# Altium schematic sheet HALL_SENSOR.SchDoc(HALL_SENSOR)
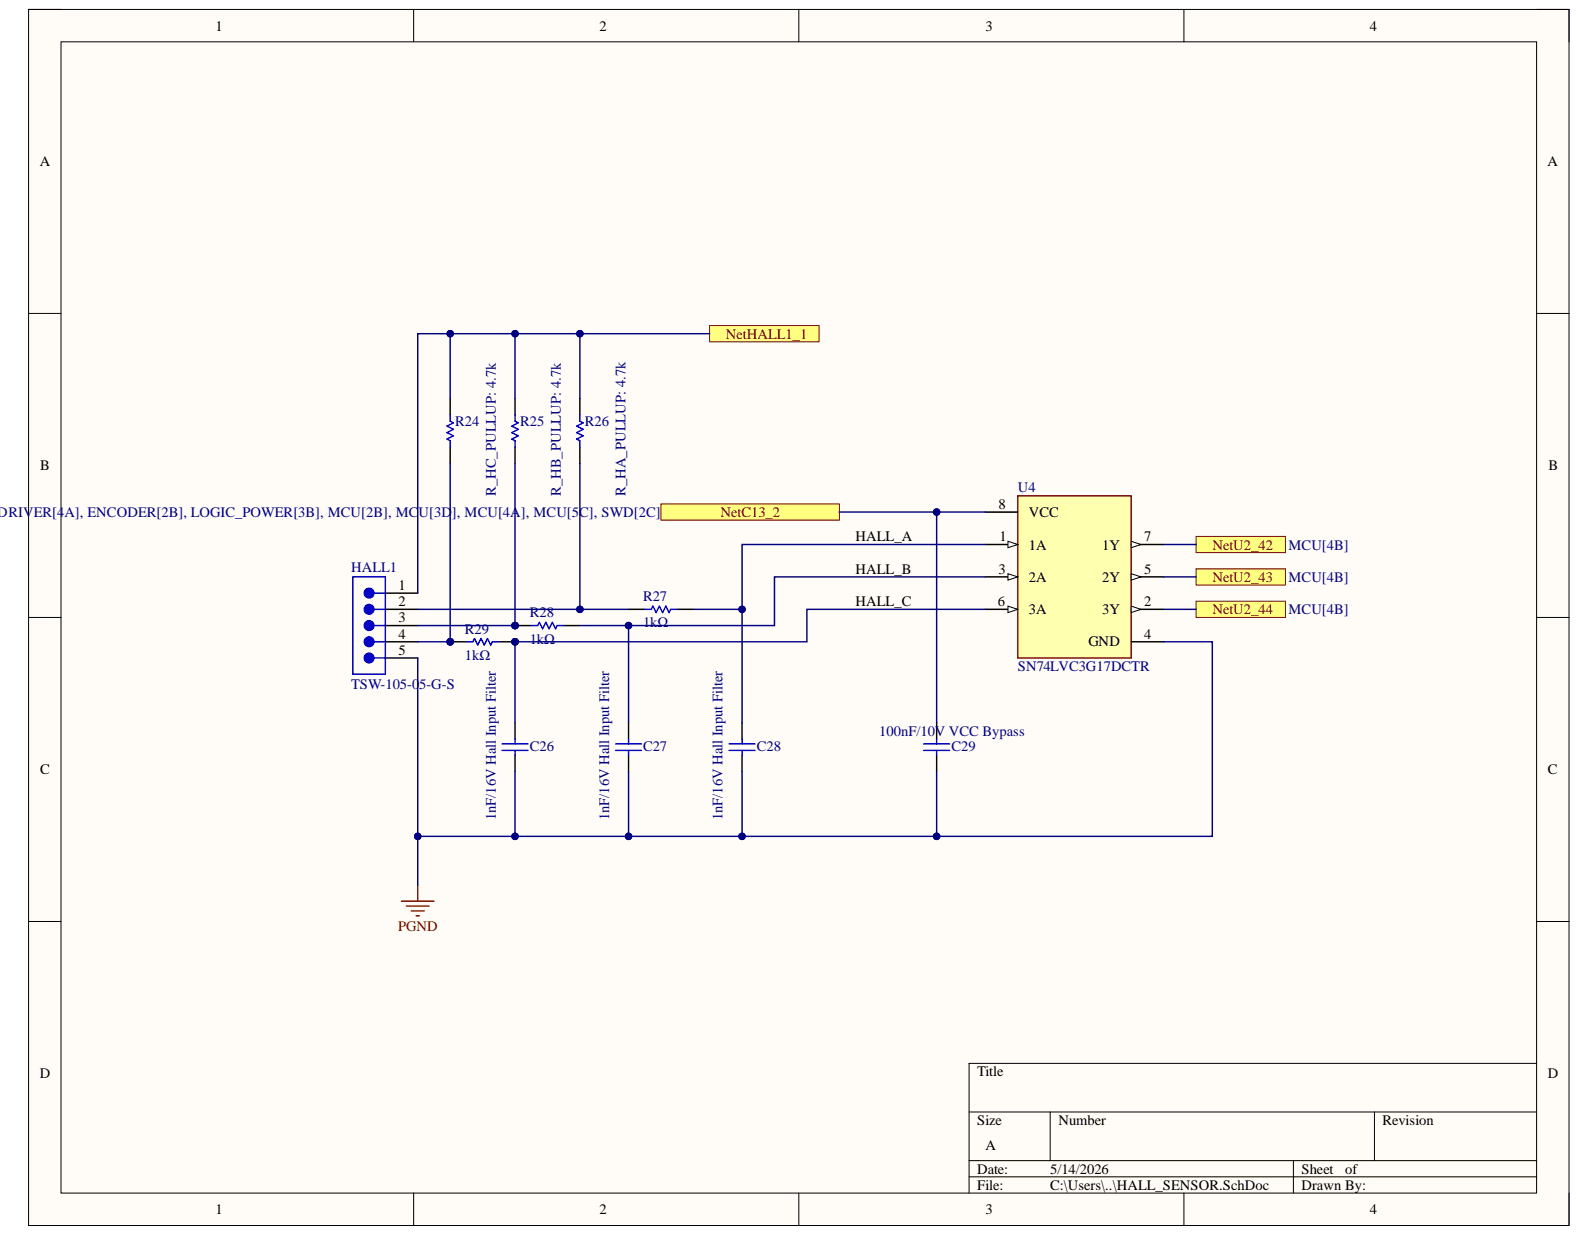
[diagram p0: HALL_SENSOR.SchDoc]

## Parts
C26  1nF/16V Hall Input Filter  [CAPC2013X145X50ML15T20]
C27  1nF/16V Hall Input Filter  [CAPC2013X145X50ML15T20]
C28  1nF/16V Hall Input Filter  [CAPC2013X145X50ML15T20]
C29  100nF/10V VCC Bypass  [CAPC0805(2012)100_N]
HALL1  TSW-105-05-G-S  [SMTC-TSW-105-05-X-S]
R24  R_HC_PULLUP: 4.7k  [RESC3225X60X45NL20T20]
R25  R_HB_PULLUP: 4.7k  [RESC3225X60X45NL20T20]
R26  R_HA_PULLUP: 4.7k  [RESC3225X60X45NL20T20]
R27  1kΩ  [RESC2013X50X30ML20T20]
R28  1kΩ  [RESC2013X50X30ML20T20]
R29  1kΩ  [RESC2013X50X30ML20T20]
U4  SN74LVC3G17DCTR  [FP-DCT0008A-MFG]

## Ports
3.3V-LOGIC-SUPPLY, 5V-SUPPLY, HALL-A, HALL-B, HALL-C

## Nets
GND: C26.1 C27.1 C28.1 C29.1 HALL1.5 U4.4(GND)
3.3V-LOGIC-SUPPLY: C29.2 U4.8(VCC) ; port 3.3V-LOGIC-SUPPLY
HALL-A: U4.7(1Y) ; port HALL-A
HALL-B: U4.5(2Y) ; port HALL-B
HALL-C: U4.2(3Y) ; port HALL-C
HALL_A: C28.2 R27.2 U4.1(1A)
HALL_B: C27.2 R28.2 U4.3(2A)
HALL_C: C26.2 R29.2 U4.6(3A)
NetHALL1_1: HALL1.1 R24.2 R25.2 R26.2 ; port 5V-SUPPLY
NetHALL1_2: HALL1.2 R26.1 R27.1
NetHALL1_3: HALL1.3 R25.1 R28.1
NetHALL1_4: HALL1.4 R24.1 R29.1

## Library data of the parts
C26-C28 = CMP-2007-01689-1: Manufacturer: Vitramon; Part Number: VJ0805Y102KXJCW1BC; Component Kind: Standard; Library Name: Altium Content Vault
C29 = CMP-1036-04396-1: CAP 100nF 10V ±20% 0805 (2012 Metric) Thickness 1mm SMD; Case-EIA: 0805; Case-Metric: 2012; Tolerance: Â±20%; Component Kind: Standard; Library Name: Altium Content Vault; Max Thickness: 1 mm; Rated Voltage: 10 V; Technology: SMT; Value: 100nF
HALL1 = CMP-1024-00363-1: 0.025" SQ Post Header, Through-hole, Vertical, -55 to 125 degC, 2.54 mm Pitch, 5-Pin, Male, RoHS; Manufacturer: Samtec; Pitch: 2.54 mm; Component Kind: Standard; ComponentLink1Description: Manufacturer URL; ComponentLink1URL: http://www.samtec.com; ComponentLink2Description: Datasheet; ComponentLink2URL: http://www.samtec.com/documents/webfiles/pdf/TSW_TH.PDF; ComponentLink3Description: Package Specification; ComponentLink3URL: http://www.samtec.com/documents/webfiles/cpdf/TSW-XXX-XX-XXX-X-XX-XXX-MKT.pdf; DatasheetVersion: F-214; Library Name: Altium Content Vault; Mounting Technology: Through-hole; Orientation: Vertical; PackageDescription: .025[0.64] SQ. Post Terminal Strip Assembly; PackageReference: TSW-105-05-X-S; PackageVersion: DM, 8/1988; PartNumber: TSW-105-05-G-S; RoHS: True; Temperature Range: -55 to 125 degC
R24-R26 = CMP-2005-00462-1: Manufacturer: Vishay Dale; Part Number: CRCW12104K70JNEAHP; Component Kind: Standard; Library Name: Altium Content Vault
R27-R29 = CMP-2001-04268-1: Manufacturer: Vishay Dale; Part Number: CRCW08051K00FKTC; Component Kind: Standard; Library Name: Altium Content Vault
U4 = CMP-0859-00525-5: IC BUFFER NON-INVERT 5.5V SM8; Manufacturer: Texas Instruments; Logic Function Desc: Non-Inverting; Min Supply Voltage: 1.65V; Nominal Supply Current: 10uA; Number Of Circuits: 3; Propagation Delay: 5.1ns; Standoff Height: 0mm; Ambient Temperature Range High: +125°C; Ambient Temperature Range Low: -40°C; Automotive: No; Category: IC; Component Kind: Standard; ComponentLink2Description: Datasheet; ComponentLink2URL: http://www.ti.com/lit/ds/symlink/sn74lvc3g17.pdf; Device Class L1: Integrated Circuits (ICs); Device Class L2: Logic ICs; Device Class L3: Buffers; Digikey Description: IC BUFFER NON-INVERT 5.5V SM8; Height: 1.3mm; Ipc Land Pattern Name: SOP65P400X130-8; Lead Free: Yes; Library Name: Altium Content Vault; Manufacturer Part Number: SN74LVC3G17DCTR; Max Supply Voltage: 5.5V; Mouser Description: Buffers & Line Drivers TRIPLE SCHMITT- TRIGGER BUFFER; Number Of Inputs: 3; Number Of Outputs: 3; Octopart: https://octopart.com/sn74lvc3g17dctr-texas+instruments-423176; Package: DCT0008A; RoHS: TRUE; Temperature: -40°C to +125°C; Temperature Range High: +125°C; Temperature Range Low: -40°C
